# Altium schematic sheet ISO_COMMS.SchDoc
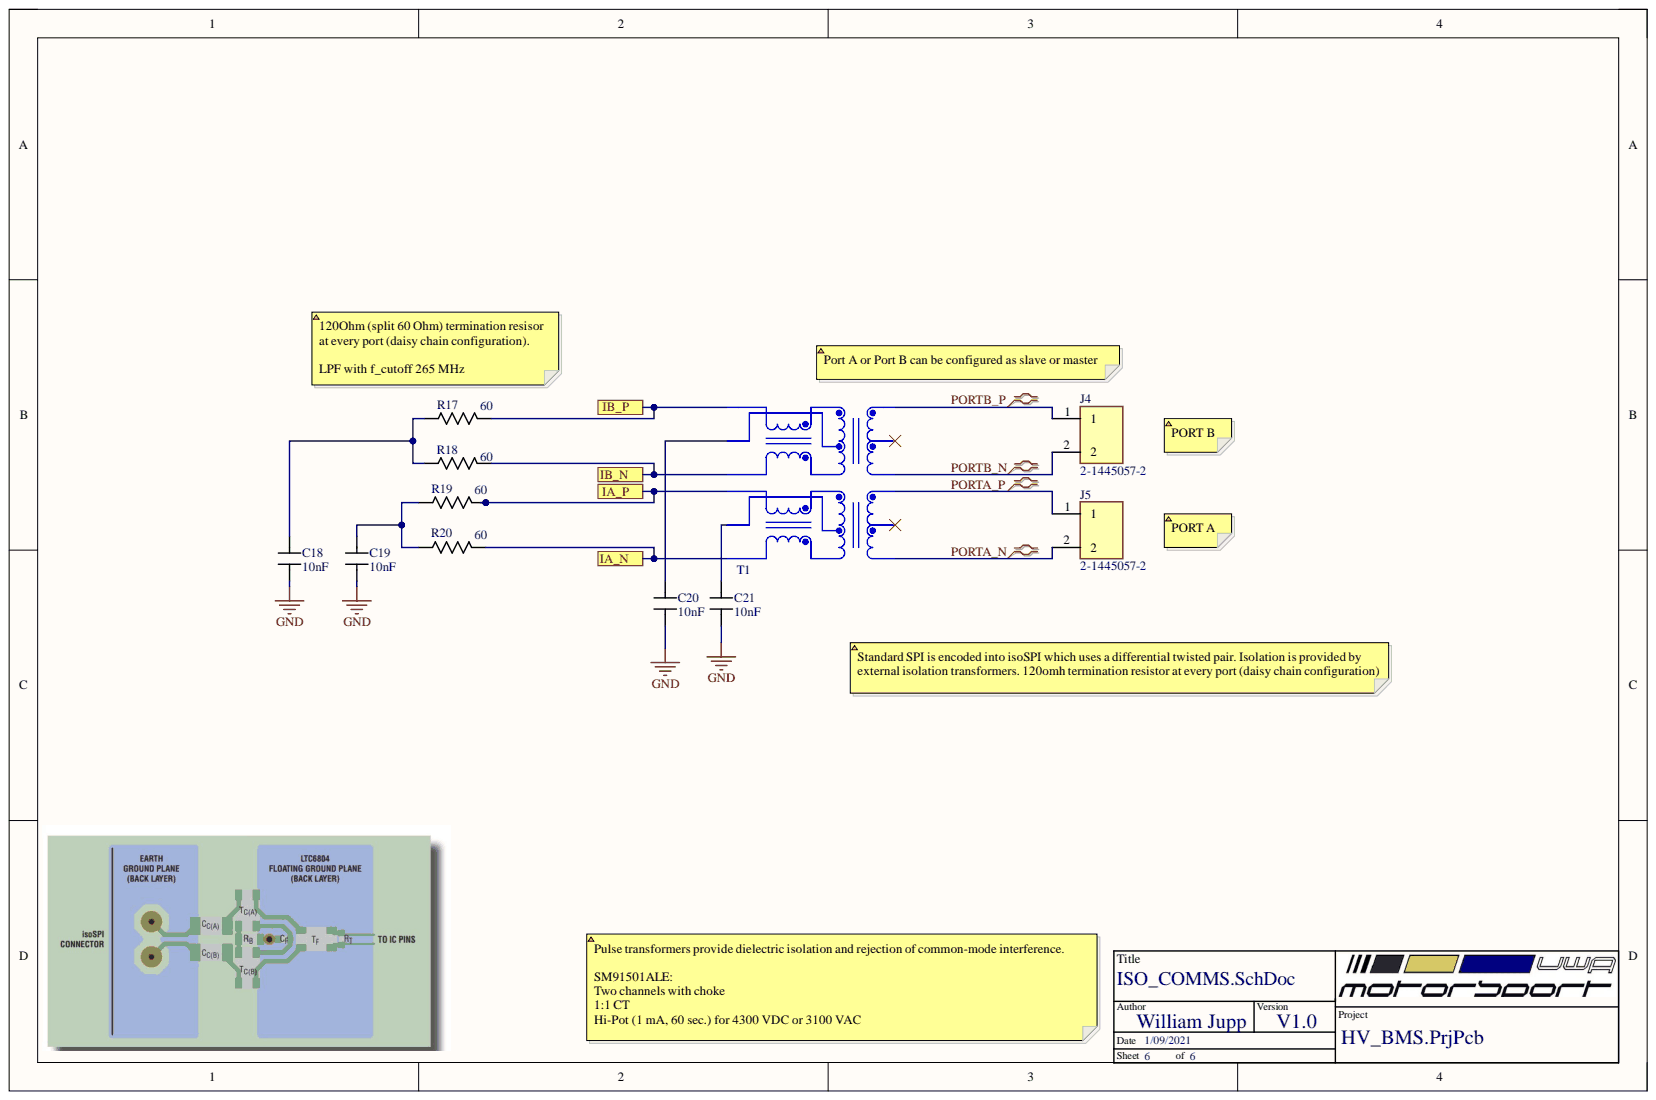
[diagram p0: ISO_COMMS.SchDoc]

## Parts
C18  C  value 10nF  [C_0603]
C19  C  value 10nF  [C_0603]
C20  C  value 10nF  [C_0603]
C21  C  value 10nF  [C_0603]
J4  2-1445057-2  [TE_2-1445057-2]
J5  2-1445057-2  [TE_2-1445057-2]
R17  value 60  [R-0603]  (hidden comment: Resistor)
R18  value 60  [R-0603]  (hidden comment: Resistor)
R19  value 60  [R-0603]  (hidden comment: Resistor)
R20  value 60  [R-0603]  (hidden comment: Resistor)
T1  SM91501ALE  [SM91501ALE]

## Ports
IA_N, IA_P, IB_N, IB_P

## Nets
GND: C18.1 C19.1 C20.2 C21.1
IA_N: R20.1 T1.7 ; port IA_N
IA_P: R19.2 T1.9 ; port IA_P
IB_N: R18.1 T1.10 ; port IB_N
IB_P: R17.2 T1.12 ; port IB_P
PORTA_N: J5.2 T1.6
PORTA_P: J5.1 T1.4
PORTB_N: J4.2 T1.3
PORTB_P: J4.1 T1.1
NetC18_2: C18.2 R17.1 R18.2
NetC19_2: C19.2 R19.1 R20.2
NetC20_1: C20.1 T1.11
NetC21_2: C21.2 T1.8

Not connected: T1 2 5

## Library data of the parts
C18-C21 = Capacitor: Component Kind: Standard; ComponentLink1Description: New link; Description: Capacitor; Library Name: Passives.IntLib
J4 = 2-1445057-2: CONN HEADER 3MM 2POS R/A TIN SMD--; MF: {'manufacturer': u'TE Connectivity'}; Availability: Unavailable; Component Kind: Standard; Library Name: SnapEDA_Library.IntLib; MP: 2-1445057-2; Package: None; Price: None
J5 = 2-1445057-2: CONN HEADER 3MM 2POS R/A TIN SMD; MF: {'manufacturer': u'TE Connectivity'}; Availability: Unavailable; Component Kind: Standard; Library Name: SnapEDA_Library.IntLib; MP: 2-1445057-2; Package: None; Price: None
T1 = SM91501ALE: BMS XFORMER 2-CH 1600V AECQ +125; Manufacturer: Bourns; Inductance: 150uH; Turns Ratio: 1:1; Component Kind: Standard; ComponentLink1Description: https://www.bourns.com/docs/product-datasheets/sm91501al.pdf?src-supplier=Digi-Key; ComponentLink1URL: https://www.bourns.com/docs/product-datasheets/sm91501al.pdf?src-supplier=Digi-Key; Library Name: HV_BMS_SCH_LIB.SchLib; Manufacturer Part Number: SM91501ALE; Max Operating Temperature: 125°C; Min Operating Temperature: -40°C; Mount: Surface Mount; Packaging: Cut Tape; Pricing 1: 1=5.20000, 10=4.21000, 50=3.14000, 100=3.05000, 1000=2.78000, 10000=2.78000 (USD); RoHS: No; Stock 1: 566; Supplier 1: Mouser; Supplier Part Number 1: 652-SM91501ALE
R17-R20 = Resistor: Component Kind: Standard; Description: Resistor; Library Name: Passives.IntLib
